# Altium schematic sheet 2.5V_REF.SchDoc
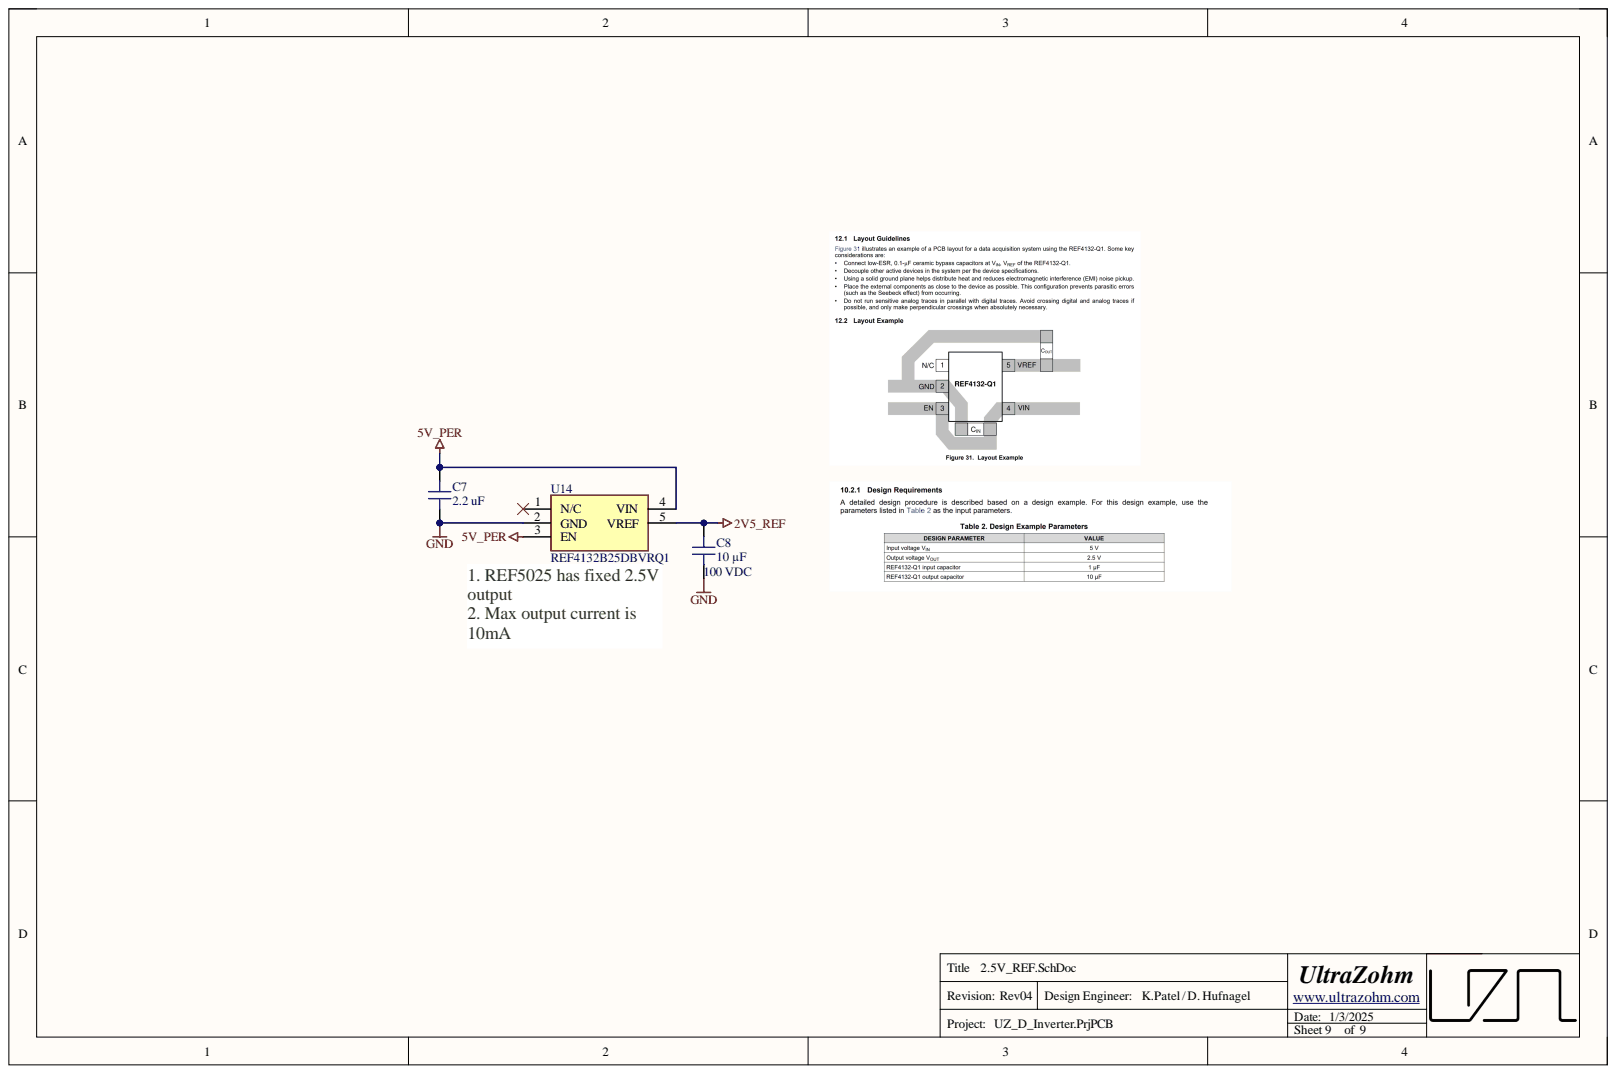
[diagram p0: 2.5V_REF.SchDoc]

## Parts
C7  2.2 uF  [C_0603]
C8  10 µF  Voltage Rating: 100 VDC  [C_1210_N]
U14  REF4132B25DBVRQ1  value 2.5 V  [SOT95P280X145-5N]

## Nets
2V5_REF: C8.2 U14.5(VREF)
5V_PER: C7.2 U14.3(EN) U14.4(VIN)
GND: C7.1 C8.1 U14.2(GND)

Not connected: U14 1(N/C)

## Library data of the parts
C7 = C1608X5R1E225K080AB: Capacitor 0603, 25 VDC, 2.2 uF, X5R; Manufacturer: TDK; Created from: DHufnagel; Database Table Name: Capacitors - SMD; Tolerance: 10 %; Voltage Rating: 25VDC; Component Kind: Standard; Component Type: Capacitor 0603; ComponentLink1Description: Datasheet; ComponentLink1URL: https://product.tdk.com/system/files/dam/doc/product/capacitor/ceramic/mlcc/catalog/mlcc_commercial_general_en.pdf?ref_disty=mouser; Created On: 20.07.2022 09:02:16; Device Package: 0603; Last Updated: 20.07.2022 09:02:16; LCSC Part Number: C2929194; Library Name: user.DbLib; Live Cycle Status: 1; Manufacturer Part Number: C1608X5R1E225K080AB; Mounting Type: SMD; Operating Temperature: -55 - 85°C; PartID: 96; Series: X5R; Stock number: not stored; Supplier 1: Mouser; Supplier 2: LCSC; Supplier Part Number 1: 810-C1608X5R1E225K; Supplier Part Number 2: C2929194; Type: Capacitor; Value: 2.2 uF
C8 = GRM32EC72A106KE05L: Capacitor 1210, 100 VDC, 10µF, X7S; Manufacturer: Murata Electronics; Created from: DHufnagel; Database Table Name: Capacitors - SMD; Tolerance: 10 %; Component Kind: Standard; Component Type: Capacitor 1210; ComponentLink1Description: Datasheet; ComponentLink1URL: https://www.mouser.de/datasheet/2/281/1/GRM32EC72A106KE05_01A-1988109.pdf; Created On: 21.12.2022 14:25:08; Device Package: 1210; Last Updated: 21.02.2024 14:40:52; LCSC Part Number: C576517; Library Name: user.DbLib; Live Cycle Status: 1; Manufacturer Part Number: GRM32EC72A106KE05L; Mounting Type: SMD; Operating Temperature: -55 - 125°C; PartID: 118; Series: GRM; Stock number: not stored; Supplier 1: Mouser; Supplier 2: LCSC; Supplier Part Number 1: 81-GRM32EC72A106KE05; Supplier Part Number 2: C576517; Type: Capacitor; Value: 10 µF
U14 = REF4132B25DBVRQ1: Voltage Reference, 2.5V, ±0.05%, ±10mA; Manufacturer: Texas Instruments; Created from: DHufnagel; Database Table Name: Power Supply - Linear; Component Kind: Standard; Component Type: Voltage Reference; ComponentLink1Description: Datasheet; ComponentLink1URL: https://www.ti.com/general/docs/suppproductinfo.tsp?distId=26&gotoUrl=https://www.ti.com/lit/gpn/ref4132-q1; Created On: 08.07.2022 12:48:56; Device Package: SMD; Last Updated: 08.07.2022 12:59:37; Library Name: user.DbLib; Live Cycle Status: 1; Manufacturer Part Number: REF4132B25DBVRQ1; Mounting Type: SMD; Operating Temperature: -40 - 125 °C; PartID: 10; Series: -40 - 125 °C; Stock number: not stored; Supplier 1: Mouser; Supplier 2: Digi-Key; Supplier Part Number 1: 595-REF4132B25DBVRQ1; Supplier Part Number 2: 296-REF4132B25DBVRQ1CT-ND; Type: Voltage Reference; Value: 2.5 V
